# Altium schematic sheet MKS MINI FOC V1.0.SchDoc
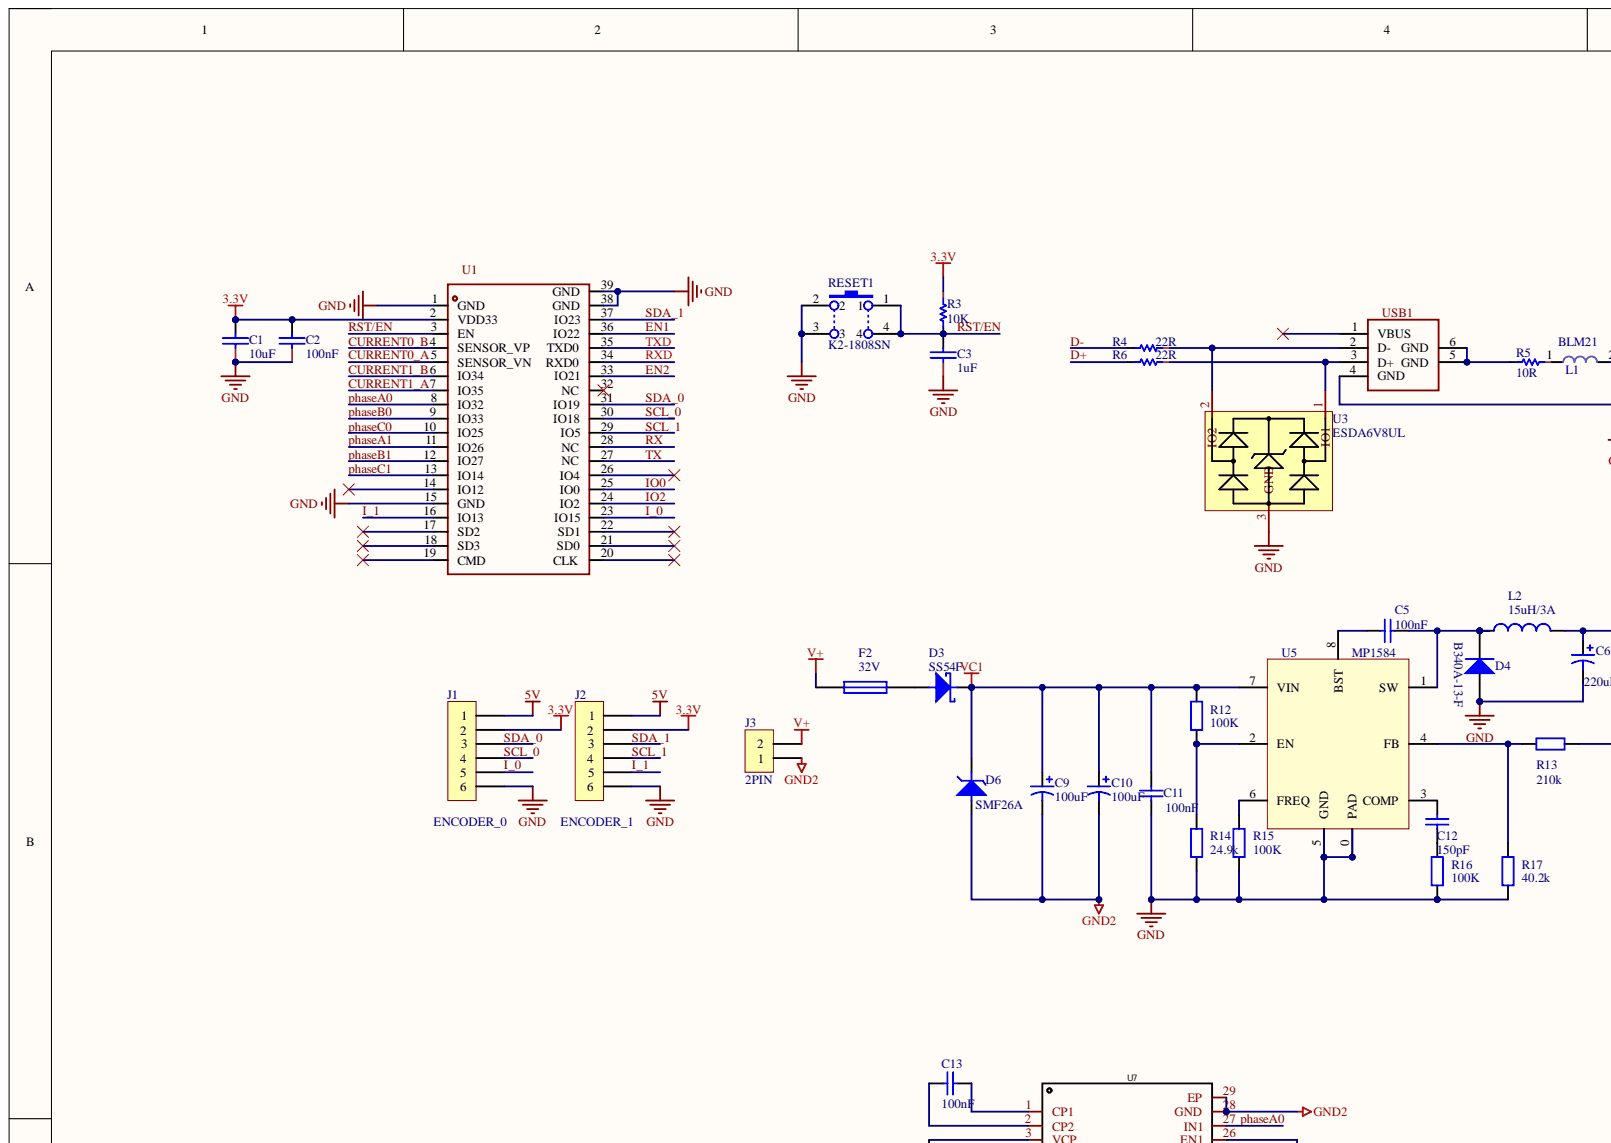
[diagram p0: MKS MINI FOC V1.0.SchDoc, top left]
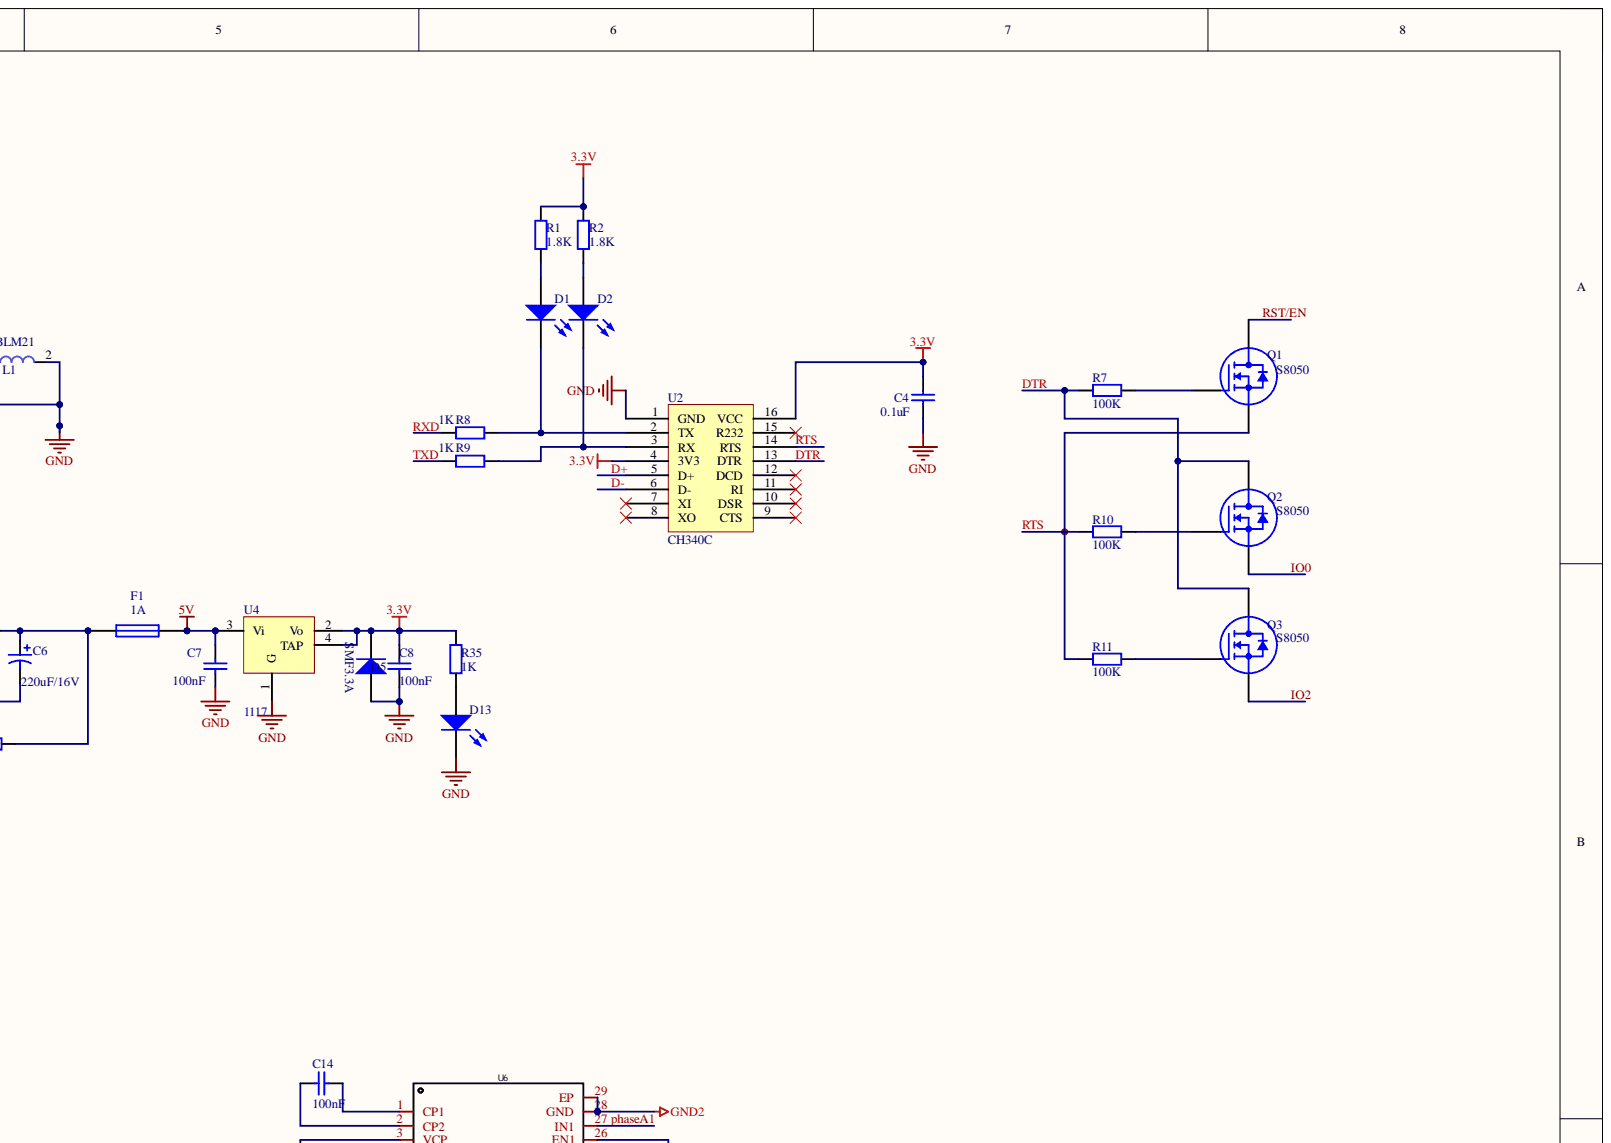
[diagram p1: MKS MINI FOC V1.0.SchDoc, top right]
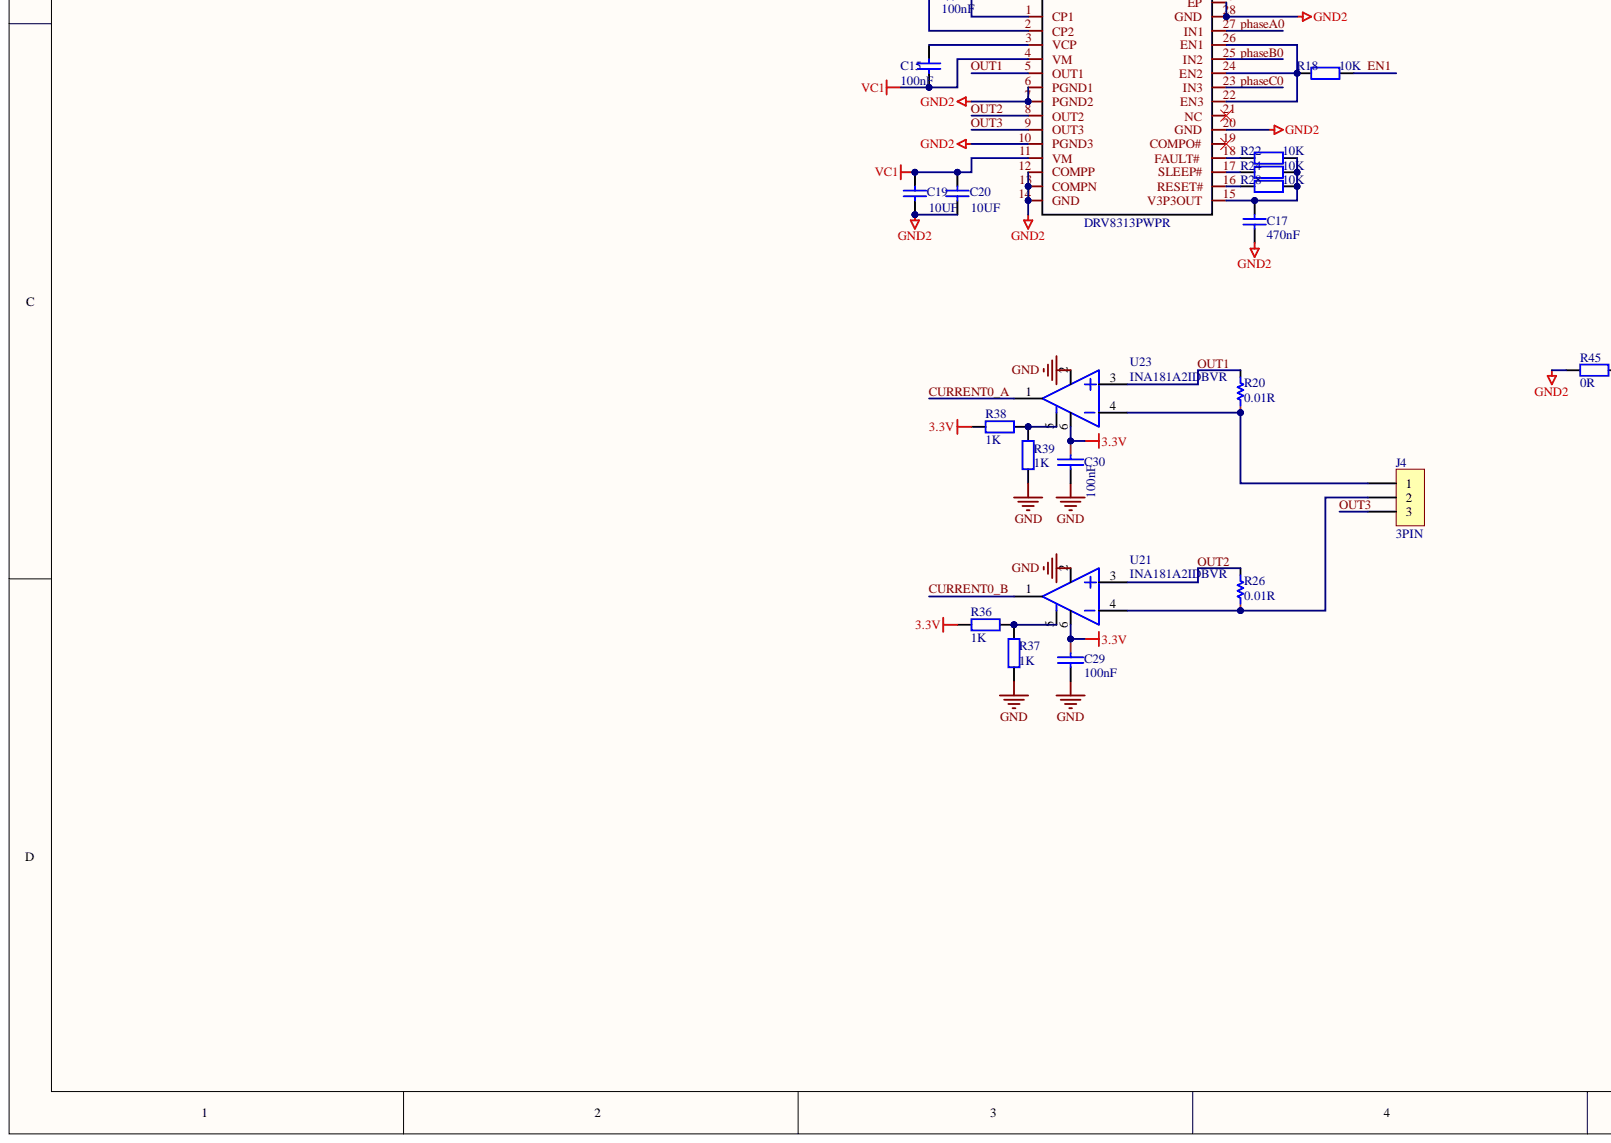
[diagram p2: MKS MINI FOC V1.0.SchDoc, bottom left]
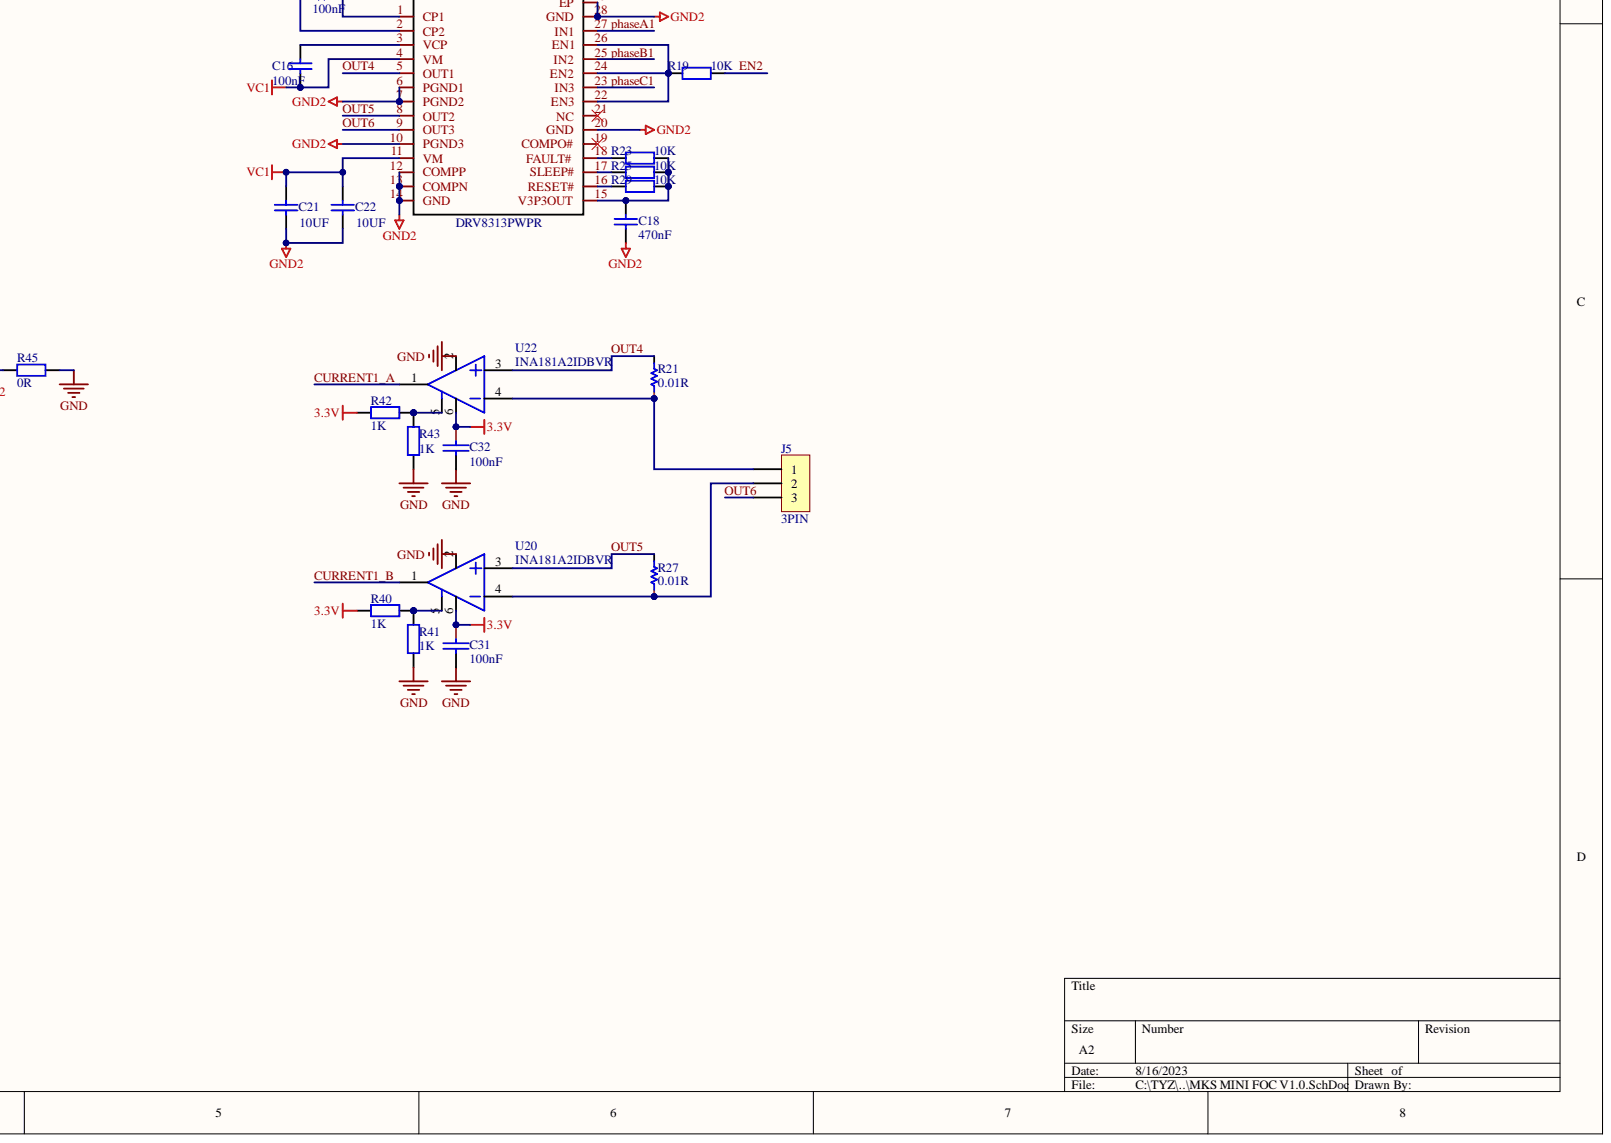
[diagram p3: MKS MINI FOC V1.0.SchDoc, bottom right]

## Parts
C1  10uF  [C0603]
C2  100nF  [C0603]
C3  1uF  [C0603]
C4  0.1uF  [C0603]
C5  100nF  [C0603]
C6  220uF/16V  [SMD-6.3*7.7mm(RVT)]
C7  100nF  [C0603]
C8  100nF  [C0603]
C9  100uF  [SMD-6.3*7.7mm(RVT)]
C10  100uF  [SMD-6.3*7.7mm(RVT)]
C11  100nF  [C0603]
C12  150pF  [C0603]
C13  100nF  [C0603]
C14  100nF  [C0603]
C15  100nF  [C0603]
C16  100nF  [C0603]
C17  470nF  [C0603]
C18  470nF  [C0603]
C19  10UF  [C1206]
C20  10UF  [C1206]
C21  10UF  [C1206]
C22  10UF  [C1206]
C29  100nF  [C0603]
C30  100nF  [C0603]
C31  100nF  [C0603]
C32  100nF  [C0603]
D1  LED  [LED0603]  (value not drawn)
D2  LED  [LED0603]  (value not drawn)
D3  SS54F  [D_SMAF]
D4  B340A-13-F  [D_SMAF]
D5  SMF3.3A  [SOD-123]
D6  SMF26A  [SOD-123]
D13  LED  [LED0603]  (value not drawn)
F1  1A  [FU1206]
F2  32V  [FU1206]
J1  ENCODER_0  [XH2.54-6P]
J2  ENCODER_1  [XH2.54-6P]
J3  2PIN  [J5.0MM-2P]
J4  3PIN  [J5.0MM-3P]
J5  3PIN  [J5.0MM-3P]
L1  BLM21  [R0805]
L2  15uH/3A  [L7*7*4]
Q1  S8050  [SOP-23]
Q2  S8050  [SOP-23]
Q3  S8050  [SOP-23]
R1  1.8K  [R0603]
R2  1.8K  [R0603]
R3  10K  [R0603]
R4  22R  [R0603]
R5  10R  [R0805]
R6  22R  [R0603]
R7  100K  [R0603]
R8  1K  [R0603]
R9  1K  [R0603]
R10  100K  [R0603]
R11  100K  [R0603]
R12  100K  [R0603]
R13  210k  [R0603]
R14  24.9k  [R0603]
R15  100K  [R0603]
R16  100K  [R0603]
R17  40.2k  [R0603]
R18  10K  [R0603]
R19  10K  [R0603]
R20  0.01R  [R1206]
R21  0.01R  [R1206]
R22  10K  [R0603]
R23  10K  [R0603]
R24  10K  [R0603]
R25  10K  [R0603]
R26  0.01R  [R1206]
R27  0.01R  [R1206]
R28  10K  [R0603]
R29  10K  [R0603]
R35  1K  [R0603]
R36  1K  [R0603]
R37  1K  [R0603]
R38  1K  [R0603]
R39  1K  [R0603]
R40  1K  [R0603]
R41  1K  [R0603]
R42  1K  [R0603]
R43  1K  [R0603]
R45  0R  [R0805]
RESET1  K2-1808SN  [K2_1808SN]
U1  ESP32-WROVER-E(4MB)_C529587  [ESP32]  (value not drawn)
U2  CH340C  [SOP-16]
U3  ESDA6V8UL  [SOP-23]
U4  1117  [SOT-223]
U5  MP1584  [SOIC-8E]
U6  DRV8313PWPR  [HTSSOP-28]
U7  DRV8313PWPR  [HTSSOP-28]
U20  INA181A2IDBVR  [SOP-23-6]
U21  INA181A2IDBVR  [SOP-23-6]
U22  INA181A2IDBVR  [SOP-23-6]
U23  INA181A2IDBVR  [SOP-23-6]
USB1  USB-B01  [USB-B]  (value not drawn)

## Nets
3.3V: C1.1 C2.1 C4.2 C8.2 C29.2 C30.2 C31.2 C32.2 D5.2 J1.2 J2.2 R1.2 R2.2 R3.2 R35.2 R36.2 R38.2 R40.2 R42.2 U1.2(VDD33) U2.4(3V3) U2.16(VCC) U4.2(Vo) U4.4(TAP) U20.6 U21.6 U22.6 U23.6
5V: C7.2 F1.1 J1.1 J2.1 U4.3(Vi)
GND: C1.2 C2.2 C3.2 C4.1 C6.2 C7.1 C8.1 C11.1 C29.1 C30.1 C31.1 C32.1 D4.1 D5.1 D13.2 J1.6 J2.6 L1.2 R14.2 R15.2 R16.2 R17.2 R37.1 R39.1 R41.1 R43.1 R45.1 RESET1.2 RESET1.3 U1.1(GND) U1.15(GND) U1.38(GND) U1.39(GND) U2.1(GND) U3.3 U4.1(G) U5.0(PAD) U5.5(GND) U20.2 U21.2 U22.2 U23.2 USB1.4(GND)
GND2: C9.2 C10.2 C17.2 C18.2 C19.1 C20.1 C21.1 C22.1 D6.1 J3.1 R45.2 U6.6(PGND1) U6.7(PGND2) U6.10(PGND3) U6.12(COMPP) U6.13(COMPN) U6.14(GND) U6.20(GND) U6.28(GND) U6.29(EP) U7.6(PGND1) U7.7(PGND2) U7.10(PGND3) U7.12(COMPP) U7.13(COMPN) U7.14(GND) U7.20(GND) U7.28(GND) U7.29(EP)
V+: F2.2 J3.2
VC1: C9.1 C10.1 C11.2 C15.1 C16.1 C19.2 C20.2 C21.2 C22.2 D3.2 D6.2 R12.1 U5.7(VIN) U6.4(VM) U6.11(VM) U7.4(VM) U7.11(VM)
CURRENT0_A: U1.5(SENSOR_VN) U23.1
CURRENT0_B: U1.4(SENSOR_VP) U21.1
CURRENT1_A: U1.7(IO35) U22.1
CURRENT1_B: U1.6(IO34) U20.1
D+: R6.1 U2.5(D+)
D-: R4.1 U2.6(D-)
DTR: Q2.3 Q3.3 R7.1 U2.13(DTR)
EN1: R18.2 U1.36(IO22)
EN2: R19.2 U1.33(IO21)
I_0: J1.5 U1.23(IO15)
I_1: J2.5 U1.16(IO13)
IO0: Q2.2 U1.25(IO0)
IO2: Q3.2 U1.24(IO2)
OUT1: R20.1 U7.5(OUT1) U23.3
OUT2: R26.1 U7.8(OUT2) U21.3
OUT3: J4.3 U7.9(OUT3)
OUT4: R21.1 U6.5(OUT1) U22.3
OUT5: R27.1 U6.8(OUT2) U20.3
OUT6: J5.3 U6.9(OUT3)
phaseA0: U1.8(IO32) U7.27(IN1)
phaseA1: U1.11(IO26) U6.27(IN1)
phaseB0: U1.9(IO33) U7.25(IN2)
phaseB1: U1.12(IO27) U6.25(IN2)
phaseC0: U1.10(IO25) U7.23(IN3)
phaseC1: U1.13(IO14) U6.23(IN3)
RST/EN: C3.1 Q1.3 R3.1 RESET1.1 RESET1.4 U1.3(EN)
RTS: Q1.2 R10.1 R11.1 U2.14(RTS)
RX: U1.28(NC)
RXD: R8.2 U1.34(RXD0)
SCL_0: J1.4 U1.30(IO18)
SCL_1: J2.4 U1.29(IO5)
SDA_0: J1.3 U1.31(IO19)
SDA_1: J2.3 U1.37(IO23)
TX: U1.27(NC)
TXD: R9.2 U1.35(TXD0)
NetC5_1: C5.1 U5.8(BST)
NetC5_2: C5.2 D4.2 L2.1 U5.1(SW)
NetC6_1: C6.1 F1.2 L2.2 R13.2
NetC12_1: C12.1 R16.1
NetC12_2: C12.2 U5.3(COMP)
NetC13_1: C13.1 U7.2(CP2)
NetC13_2: C13.2 U7.1(CP1)
NetC14_1: C14.1 U6.2(CP2)
NetC14_2: C14.2 U6.1(CP1)
NetC15_2: C15.2 U7.3(VCP)
NetC16_2: C16.2 U6.3(VCP)
NetC17_1: C17.1 R22.2 R24.2 R28.2 U7.15(V3P3OUT)
NetC18_1: C18.1 R23.2 R25.2 R29.2 U6.15(V3P3OUT)
NetD1_1: D1.1 R1.1
NetD1_2: D1.2 R8.1 U2.2(TX)
NetD2_1: D2.1 R2.1
NetD2_2: D2.2 R9.1 U2.3(RX)
NetD3_1: D3.1 F2.1
NetD13_1: D13.1 R35.1
NetJ4_1: J4.1 R20.2 U23.4
NetJ4_2: J4.2 R26.2 U21.4
NetJ5_1: J5.1 R21.2 U22.4
NetJ5_2: J5.2 R27.2 U20.4
NetL1_1: L1.1 R5.2
NetQ1_1: Q1.1 R7.2
NetQ2_1: Q2.1 R10.2
NetQ3_1: Q3.1 R11.2
NetR4_2: R4.2 U3.2 USB1.2(D-)
NetR5_1: R5.1 USB1.5(GND) USB1.6(GND)
NetR6_2: R6.2 U3.1 USB1.3(D+)
NetR12_2: R12.2 R14.1 U5.2(EN)
NetR13_1: R13.1 R17.1 U5.4(FB)
NetR15_1: R15.1 U5.6(FREQ)
NetR18_1: R18.1 U7.22(EN3) U7.24(EN2) U7.26(EN1)
NetR19_1: R19.1 U6.22(EN3) U6.24(EN2) U6.26(EN1)
NetR22_1: R22.1 U7.18(FAULT#)
NetR23_1: R23.1 U6.18(FAULT#)
NetR24_1: R24.1 U7.17(SLEEP#)
NetR25_1: R25.1 U6.17(SLEEP#)
NetR28_1: R28.1 U7.16(RESET#)
NetR29_1: R29.1 U6.16(RESET#)
NetR36_1: R36.1 R37.2 U21.5
NetR38_1: R38.1 R39.2 U23.5
NetR40_1: R40.1 R41.2 U20.5
NetR42_1: R42.1 R43.2 U22.5

Not connected: U1 14(IO12) 17(SD2) 18(SD3) 19(CMD) 20(CLK) 21(SD0) 22(SD1) 26(IO4) 32(NC); U2 7(XI) 8(XO) 9(CTS) 10(DSR) 11(RI) 12(DCD) 15(R232); U6 19(COMPO#) 21(NC); U7 19(COMPO#) 21(NC); USB1 1(VBUS)

## Library data of the parts
C1-C3 C29-C32 = CAP: Capacitor; Component Kind: Standard
C4 = Cap: Capacitor; Value: 100nF; Component Kind: Standard; LatestRevisionDate: 17-Jul-2002; LatestRevisionNote: Re-released for DXP Platform.; Library Name: Miscellaneous Devices.IntLib; PackageReference: RAD-0.3; Published: 8-Jun-2000; Publisher: Altium Limited
C5 C11 C13-C22 = Cap: Capacitor; Value: 0.1uF; Component Kind: Standard; LatestRevisionDate: 17-Jul-2002; LatestRevisionNote: Re-released for DXP Platform.; Library Name: Miscellaneous Devices.IntLib; PackageReference: RAD-0.3; Published: 8-Jun-2000; Publisher: Altium Limited
  C5 C11 C13-C18: Signal Integrity: Cap; Simulation: CAP
C6 C9 C10 = Cap Pol3: Polarized Capacitor (Surface Mount); Value: 100pF; Component Kind: Standard; LatestRevisionDate: 17-Jul-2002; LatestRevisionNote: Re-released for DXP Platform.; Library Name: Miscellaneous Devices.IntLib; PackageReference: C0805; Published: 8-Jun-2000; Signal Integrity: Cap Pol; Simulation: CAP; Publisher: Altium Limited
C7 C8 = Cap: Component Kind: Standard; Library Name: 3D.IntLib
C12 = Cap: Capacitor; Value: 100pF; Component Kind: Standard; LatestRevisionDate: 17-Jul-2002; LatestRevisionNote: Re-released for DXP Platform.; Library Name: Miscellaneous Devices.IntLib; PackageReference: RAD-0.3; Published: 8-Jun-2000; Signal Integrity: Cap; Simulation: CAP; Publisher: Altium Limited
D1 D2 D13 = LED2: Green LED; Component Kind: Standard; LatestRevisionDate: 17-Jul-2002; LatestRevisionNote: Re-released for DXP Platform.; Library Name: Miscellaneous Devices.IntLib; PackageDocument: Feb-2002; PackageReference: 3.2X1.6X1.1; Published: 8-Jun-2000; Simulation: LED2; Publisher: Altium Limited
D3 = DIS: Schottky Diode; Component Kind: Standard
D4 D5 = Diode: Default Diode; Code_JEDEC: DO-214-AB; Component Kind: Standard; LatestRevisionDate: 17-Jul-2002; LatestRevisionNote: Re-released for DXP Platform.; Library Name: Miscellaneous Devices.IntLib; PackageDocument: Sep-1996; PackageReference: SMC; Published: 8-Jun-2000; Signal Integrity: Diode; Simulation: DIODE; Publisher: Altium Limited
D6 = TVS1: Varactor Diode; Component Kind: Standard
F1 F2 = Fuse 1: Fuse; Component Kind: Standard; LatestRevisionDate: 17-Jul-2002; LatestRevisionNote: Re-released for DXP Platform.; Library Name: Miscellaneous Devices.IntLib; PackageReference: PIN-W2/E2.8; Published: 8-Jun-2000; Publisher: Altium Limited
J1 J2 = CON6: Connector; Component Kind: Standard
J3 = CON2: Connector; Component Kind: Standard
J4 J5 = CON3: Connector; Component Kind: Standard
L1 = L_1: 电感; Component Kind: Standard; Library Name: 电阻电容电感磁珠保险丝.SCHLIB
L2 = Inductor: Component Kind: Standard; Description: Inductor; Library Name: 3D.IntLib
Q1-Q3 = N-GSD: Component Kind: Standard
R1 R2 R8 R9 R13 R14 R17-R19 R22-R25 R28 R29 R35-R43 R45 = Res2: Resistor; Value: 100K; Component Kind: Standard; LatestRevisionDate: 17-Jul-2002; LatestRevisionNote: Re-released for DXP Platform.; Library Name: Miscellaneous Devices.IntLib; PackageReference: AXIAL-0.4; Published: 8-Jun-2000; Publisher: Altium Limited
  R1 R2 R8 R9 R13 R14 R17-R19 R22-R25 R28 R29 R35-R43: Signal Integrity: Res; Simulation: RESISTOR
R3-R6 R20 R21 R26 R27 = RAS: Component Kind: Standard
R7 R10-R12 R15 R16 = Res2: Resistor; Component Kind: Standard; LatestRevisionDate: 17-Jul-2002; LatestRevisionNote: Re-released for DXP Platform.; Library Name: Miscellaneous Devices.IntLib; PackageReference: AXIAL-0.4; Published: 8-Jun-2000; Signal Integrity: Res; Simulation: RESISTOR; Value: 100K; Publisher: Altium Limited
RESET1 = SWPB4/1-4_2-3: Component Kind: Standard
U1 = ESP32-WROVER-E(4MB)_C529587: Add into BOM: yes; Component Kind: Standard; Convert to PCB: yes; Datasheet: https://atta.szlcsc.com/upload/public/pdf/source/20200521/C529587_D84B0DB924D6E66FFA81DC70347AB809.pdf; Device: ESP32-WROVER-E(4MB)_C529587; JLCPCB Part Class: Extended Part; LCSC Part Name: ESP32-WROVER-E(4MB); Manufacturer Part: ESP32-WROVER-E(4MB); Name: ESP32-WROVER-E(4MB); PCB3D: WIFIM-SMD_39P-L31.4-W18.0-H3.3-P1.27; Supplier: LCSC; Supplier Footprint: Module; Supplier Part: C529587; Symbol: ESP32-WROVER-E(4MB)
U2 = Component_1: 名 类型 描述    SOP-16 Footprint TSOP, 16-Leads, Body 10.0x4.0mm (max), Pitch 1.27mm, IPC Medium Density; Component Kind: Standard; Library Name: CH340G.SchLib
U3 = UBT23A05L02: Component Kind: Standard; Library Name: ESC.SchLib
U4 = AMS1117: Component Kind: Standard; Library Name: 3D.IntLib
U6 U7 = DRV8313PWPR: Designer: U?; Component Kind: Standard; Device: DRV8313PWPR; Symbol: DRV8313PWPR
U20-U23 = ADCMP601BKSZ-REEL: Component Kind: Standard
USB1 = USB-B01: Add into BOM: yes; Connector Type: Type-B; Number of Contacts: 4; Number of Ports: 1; Component Kind: Standard; Convert to PCB: yes; Current Rating - Power (Max): -; Datasheet: https://atta.szlcsc.com/upload/public/pdf/source/20200812/C498173_CFDA774068C8841191F9DEBD85971FB5.pdf; Device: USB-B01; JLCPCB Part Class: Extended Part; Manufacturer Part: USB-B01; Name: USB-B01; Operating Temperature Range: -; PCB3D: USB-B-TH_USB-B01; Standard: USB 2.0; Supplier: LCSC; Supplier Part: C498173; Symbol: USB-B01
U5 = MP1584: Component Kind: Standard; Library Name: 3D.SchLib
